# Altium schematic sheet Ethernet_PHY.SchDoc(Ethernet_PHY)
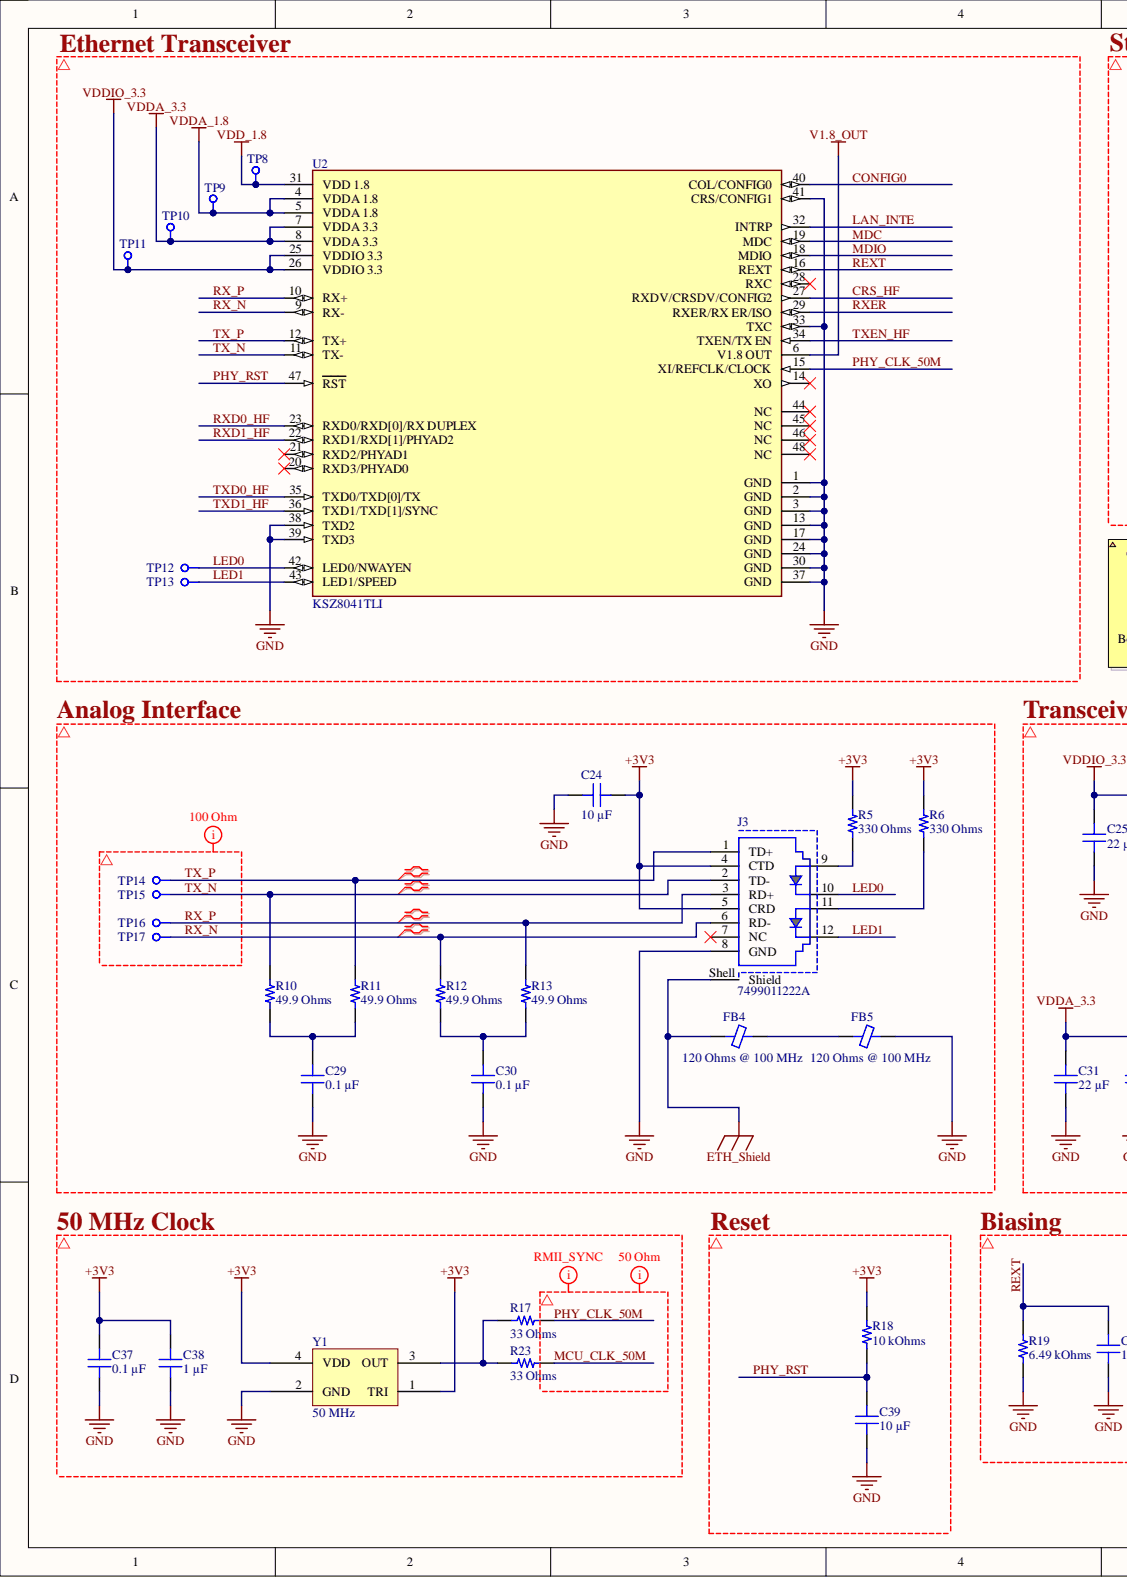
[diagram p0: Ethernet_PHY.SchDoc, left]
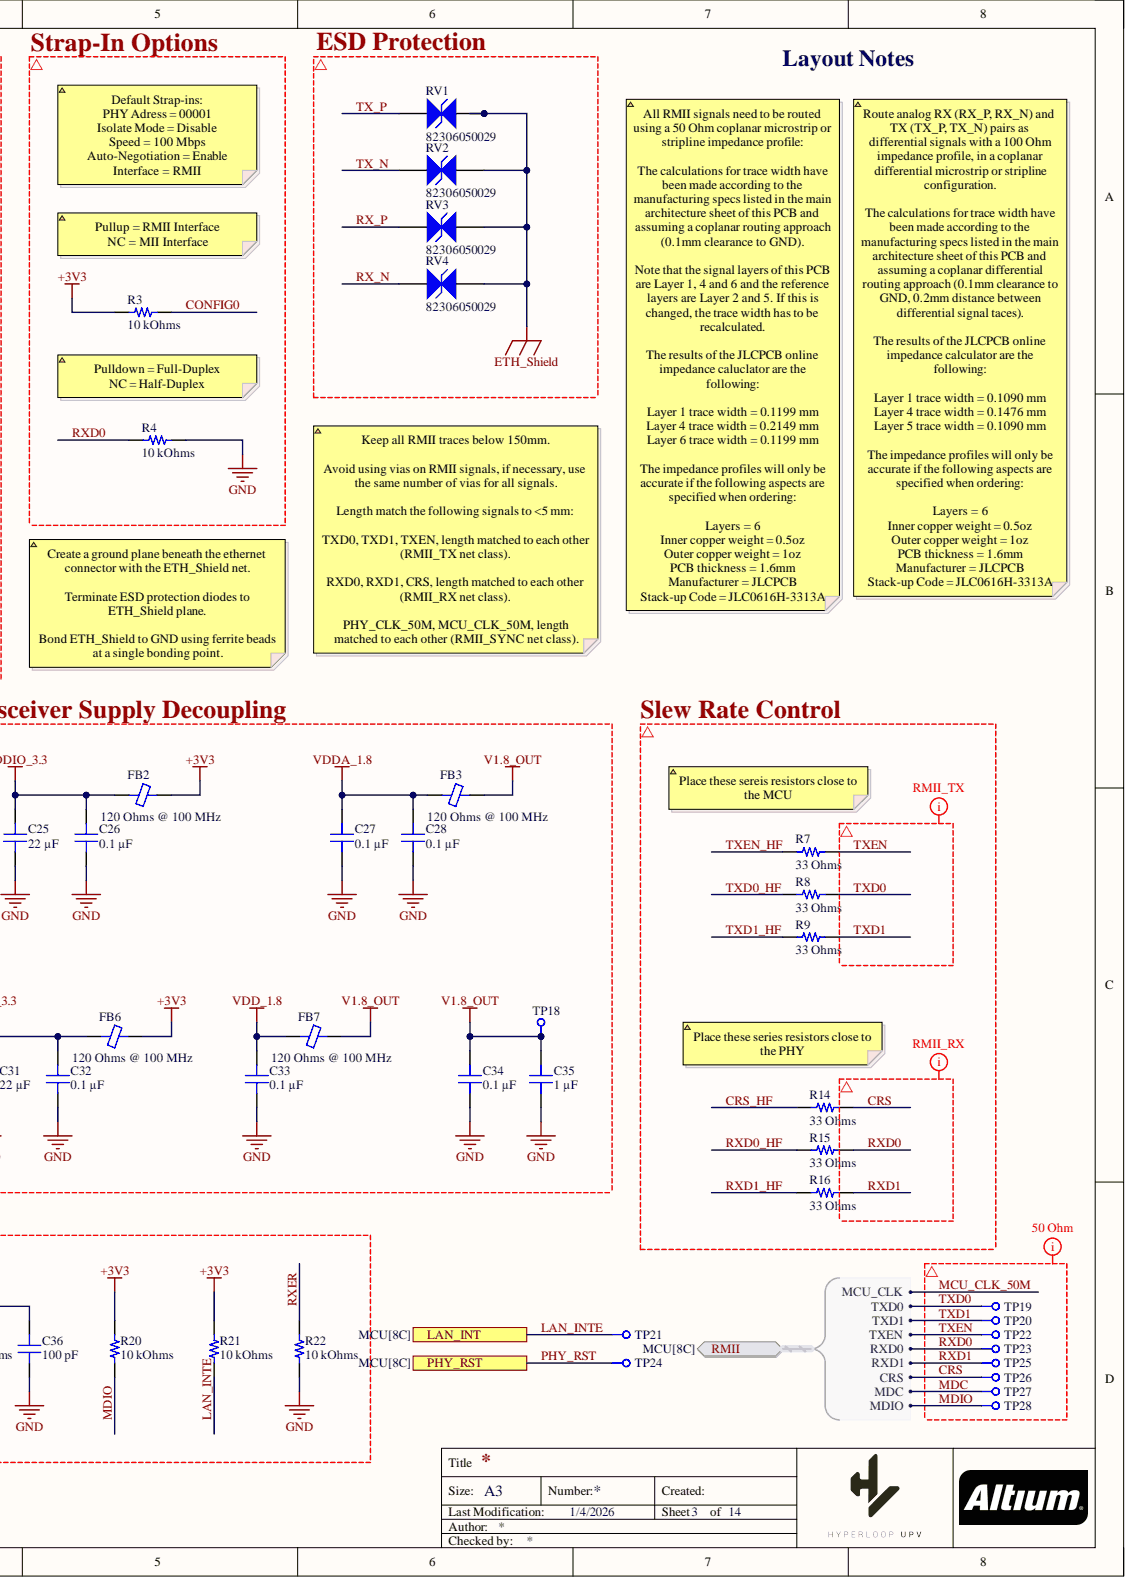
[diagram p1: Ethernet_PHY.SchDoc, right]

## Parts
C24  10 µF
C25  22 µF
C26  0.1 µF
C27  0.1 µF
C28  0.1 µF
C29  0.1 µF
C30  0.1 µF
C31  22 µF
C32  0.1 µF
C33  0.1 µF
C34  0.1 µF
C35  1 µF
C36  100 pF
C37  0.1 µF
C38  1 µF
C39  10 µF
FB2  120 Ohms @ 100 MHz
FB3  120 Ohms @ 100 MHz
FB4  120 Ohms @ 100 MHz
FB5  120 Ohms @ 100 MHz
FB6  120 Ohms @ 100 MHz
FB7  120 Ohms @ 100 MHz
J3  7499011222A
R3  10 kOhms
R4  10 kOhms
R5  330 Ohms
R6  330 Ohms
R7  33 Ohms
R8  33 Ohms
R9  33 Ohms
R10  49.9 Ohms
R11  49.9 Ohms
R12  49.9 Ohms
R13  49.9 Ohms
R14  33 Ohms
R15  33 Ohms
R16  33 Ohms
R17  33 Ohms
R18  10 kOhms
R19  6.49 kOhms
R20  10 kOhms
R21  10 kOhms
R22  10 kOhms
R23  33 Ohms
RV1  82306050029
RV2  82306050029
RV3  82306050029
RV4  82306050029
TP8  Test point 1.2mm  (value not drawn)
TP9  Test point 1.2mm  (value not drawn)
TP10  Test point 1.2mm  (value not drawn)
TP11  Test point 1.2mm  (value not drawn)
TP12  Test point 1.2mm  (value not drawn)
TP13  Test point 1.2mm  (value not drawn)
TP14  Test point 1.2mm  (value not drawn)
TP15  Test point 1.2mm  (value not drawn)
TP16  Test point 1.2mm  (value not drawn)
TP17  Test point 1.2mm  (value not drawn)
TP18  Test point 1.2mm  (value not drawn)
TP19  Test point 1.2mm  (value not drawn)
TP20  Test point 1.2mm  (value not drawn)
TP21  Test point 1.2mm  (value not drawn)
TP22  Test point 1.2mm  (value not drawn)
TP23  Test point 1.2mm  (value not drawn)
TP24  Test point 1.2mm  (value not drawn)
TP25  Test point 1.2mm  (value not drawn)
TP26  Test point 1.2mm  (value not drawn)
TP27  Test point 1.2mm  (value not drawn)
TP28  Test point 1.2mm  (value not drawn)
U2  KSZ8041TLI
Y1  50 MHz

## Ports
LAN_INT, PHY_RST, RMII, RMII.CRS, RMII.MCU_CLK, RMII.MDC, RMII.MDIO, RMII.RXD0, RMII.RXD1, RMII.TXD0, RMII.TXD1, RMII.TXEN

## Nets
+3V3: C24.2 C37.2 C38.2 FB2.2 FB6.2 J3.4(CTD) J3.5(CRD) R3.2 R5.2 R6.2 R18.2 R20.2 R21.2 Y1.1(TRI) Y1.4(VDD)
ETH_Shield: FB4.1 J3.Shell(Shield) RV1.2 RV2.2 RV3.2 RV4.2
GND: C24.1 C25.1 C26.1 C27.1 C28.1 C29.1 C30.1 C31.1 C32.1 C33.1 C34.1 C35.1 C36.1 C37.1 C38.1 C39.1 FB5.2 J3.8(GND) R4.1 R19.1 R22.1 U2.1(GND) U2.2(GND) U2.3(GND) U2.13(GND) U2.17(GND) U2.24(GND) U2.30(GND) U2.33(TXC) U2.37(GND) U2.38(TXD2) U2.39(TXD3) U2.41(CRS/CONFIG1) Y1.2(GND)
V1.8_OUT: C34.2 C35.2 FB3.2 FB7.2 TP18.1 U2.6(V1.8_OUT)
VDD_1.8: C33.2 FB7.1 TP8.1 U2.31(VDD_1.8)
VDDA_1.8: C27.2 C28.2 FB3.1 TP9.1 U2.4(VDDA_1.8) U2.5(VDDA_1.8)
VDDA_3.3: C31.2 C32.2 FB6.1 TP10.1 U2.7(VDDA_3.3) U2.8(VDDA_3.3)
VDDIO_3.3: C25.2 C26.2 FB2.1 TP11.1 U2.25(VDDIO_3.3) U2.26(VDDIO_3.3)
CONFIG0: R3.1 U2.40(COL/CONFIG0)
CRS: R14.2 TP26.1 ; port RMII
CRS_HF: R14.1 U2.27(RXDV/CRSDV/CONFIG2)
LAN_INTE: R21.1 TP21.1 U2.32(INTRP) ; port LAN_INT
LED0: J3.10 TP12.1 U2.42(LED0/NWAYEN)
LED1: J3.12 TP13.1 U2.43(LED1/SPEED)
MCU_CLK_50M: R23.2 ; port RMII
MDC: TP27.1 U2.19(MDC) ; port RMII
MDIO: R20.1 TP28.1 U2.18(MDIO) ; port RMII
PHY_CLK_50M: R17.2 U2.15(XI/REFCLK/CLOCK)
PHY_RST: C39.2 R18.1 TP24.1 U2.47(RST) ; port PHY_RST
REXT: C36.2 R19.2 U2.16(REXT)
RX_N: J3.6(RD-) R12.2 RV4.1 TP17.1 U2.9(RX-)
RX_P: J3.3(RD+) R13.2 RV3.1 TP16.1 U2.10(RX+)
RXD0: R4.2 R15.2 TP23.1 ; port RMII
RXD0_HF: R15.1 U2.23(RXD0/RXD[0]/RX_DUPLEX)
RXD1: R16.2 TP25.1 ; port RMII
RXD1_HF: R16.1 U2.22(RXD1/RXD[1]/PHYAD2)
RXER: R22.2 U2.29(RXER/RX_ER/ISO)
TX_N: J3.2(TD-) R10.2 RV2.1 TP15.1 U2.11(TX-)
TX_P: J3.1(TD+) R11.2 RV1.1 TP14.1 U2.12(TX+)
TXD0: R8.1 TP19.1 ; port RMII
TXD0_HF: R8.2 U2.35(TXD0/TXD[0]/TX)
TXD1: R9.1 TP20.1 ; port RMII
TXD1_HF: R9.2 U2.36(TXD1/TXD[1]/SYNC)
TXEN: R7.1 TP22.1 ; port RMII
TXEN_HF: R7.2 U2.34(TXEN/TX_EN)
NetC29_2: C29.2 R10.1 R11.1
NetC30_2: C30.2 R12.1 R13.1
NetFB4_2: FB4.2 FB5.1
NetJ3_9: J3.9 R5.1
NetJ3_11: J3.11 R6.1
NetR17_1: R17.1 R23.1 Y1.3(OUT)

Not connected: J3 7(NC); U2 14(XO) 20(RXD3/PHYAD0) 21(RXD2/PHYAD1) 28(RXC) 44(NC) 45(NC) 46(NC) 48(NC)

## Library data of the parts
C24 C39 = EMK316BJ106MD-T: CAP CER 10UF 16V X5R 1206; manufacturer: Taiyo Yuden; Database Table Name: Capacitors - Ceramic - 1206; Tolerance: ±20%; Applications: SMPS Filtering; Capacitance: 10 µF; Component Kind: Standard; componentlink1description: Datasheet; componentlink1url: http://www.yuden.co.jp/productdata/catalog/mlcc06_e.pdf; componentlink2description: DigiKey Link; componentlink2url: https://www.digikey.com/en/products/detail/taiyo-yuden/EMK316BJ106MD-T/6563474; Device Package: 1206 (3216 Metric); lastupdated: 04-Jun-24 19:10:41; Library Name: Celestial Altium Library - altium_library.DbLib; Lifecycle Status: Active; Minimum Order: 1; Mounting Type: Surface Mount, MLCC; Operating Temperature: -55°C ~ 85°C; Package / Case: 1206 (3216 Metric); packaging: TapeAndReel; Part Number: EMK316BJ106MD-T; Part Status: Active; partid: 18996; price: 0.04; series: M; Size / Dimension: 0.126" L x 0.063" W (3.20mm x 1.60mm); Supplier 1: DigiKey; Supplier Part Number 1: 587-4881-1-ND; Temperature Coefficient: X5R; Thickness (Max): 0.037" (0.95mm); Value: 10 µF; Voltage - Rated: 16V
C25 C31 = CL31A226KOCLFNC: CAP CER 22UF 16V X5R 1206; manufacturer: Samsung Electro-Mechanics; Database Table Name: Capacitors - Ceramic - 1206; Tolerance: ±10%; Applications: General Purpose; Capacitance: 22 µF; Component Kind: Standard; componentlink1description: Datasheet; componentlink1url: http://www.samsungsem.com/kr/support/product-search/mlcc/CL31A226KOCLFNC.jsp; componentlink2description: DigiKey Link; componentlink2url: https://www.digikey.com/en/products/detail/samsung-electro-mechanics/CL31A226KOCLFNC/3888383; Device Package: 1206 (3216 Metric); lastupdated: 04-Jun-24 19:10:41; Library Name: Celestial Altium Library - altium_library.DbLib; Lifecycle Status: Active; Minimum Order: 1; Mounting Type: Surface Mount, MLCC; Operating Temperature: -55°C ~ 85°C; Package / Case: 1206 (3216 Metric); packaging: TapeAndReel; Part Number: CL31A226KOCLFNC; Part Status: Active; partid: 18940; price: 0.09; series: CL; Size / Dimension: 0.126" L x 0.063" W (3.20mm x 1.60mm); Supplier 1: DigiKey; Supplier Part Number 1: 1276-2725-1-ND; Temperature Coefficient: X5R; Thickness (Max): 0.037" (0.95mm); Value: 22 µF; Voltage - Rated: 16V
C26-C30 C32-C34 C37 = C0603C104K5RAC7411: CAP CER 0.1UF 50V X7R 0603; manufacturer: KEMET; Database Table Name: Capacitors - Ceramic - 0603; Tolerance: ±10%; Applications: Bypass, Decoupling; Capacitance: 0.1 µF; Component Kind: Standard; componentlink1description: Datasheet; componentlink1url: https://api.kemet.com/component-edge/download/datasheet/C0603C104K5RAC7411.pdf; componentlink2description: DigiKey Link; componentlink2url: https://www.digikey.com/en/products/detail/kemet/C0603C104K5RAC7411/3317013; Device Package: 0603 (1608 Metric); lastupdated: 09-Feb-24 20:10:30; Library Name: Celestial Altium Library - altium_library.DbLib; Lifecycle Status: Active; Minimum Order: 1; Mounting Type: Surface Mount, MLCC; Operating Temperature: -55°C ~ 125°C; Package / Case: 0603 (1608 Metric); packaging: TapeAndReel; Part Number: C0603C104K5RAC7411; Part Status: Active; partid: 2233; price: 0.01; series: SMD Comm X7R; Size / Dimension: 0.063" L x 0.031" W (1.60mm x 0.80mm); Supplier 1: DigiKey; Supplier Part Number 1: 399-C0603C104K5RAC7411CT-ND; Temperature Coefficient: X7R; Thickness (Max): 0.035" (0.90mm); Value: 0.1 µF; Voltage - Rated: 50V
C35 C38 = C0603C105K4RACAUTO: CAP CER 1UF 16V X7R 0603; manufacturer: KEMET; Database Table Name: Capacitors - Ceramic - 0603; Tolerance: ±10%; Applications: Automotive, Bypass, Decoupling; Capacitance: 1 µF; Component Kind: Standard; componentlink1description: Datasheet; componentlink1url: https://api.kemet.com/component-edge/download/datasheet/C0603C105K4RACAUTO.pdf; componentlink2description: DigiKey Link; componentlink2url: https://www.digikey.com/en/products/detail/kemet/C0603C105K4RACAUTO/8635831; Device Package: 0603 (1608 Metric); lastupdated: 09-Feb-24 20:10:30; Library Name: Celestial Altium Library - altium_library.DbLib; Lifecycle Status: Active; Minimum Order: 1; Mounting Type: Surface Mount, MLCC; Operating Temperature: -55°C ~ 125°C; Package / Case: 0603 (1608 Metric); packaging: TapeAndReel; Part Number: C0603C105K4RACAUTO; Part Status: Active; partid: 2179; price: 0.01; Ratings: AEC-Q200; series: SMD Auto X7R; Size / Dimension: 0.063" L x 0.031" W (1.60mm x 0.80mm); Supplier 1: DigiKey; Supplier Part Number 1: 399-17704-1-ND; Temperature Coefficient: X7R; Thickness (Max): 0.037" (0.95mm); Value: 1 µF; Voltage - Rated: 16V
C36 = C0603C101K5GACAUTO: CAP CER 100PF 50V C0G/NP0 0603; manufacturer: KEMET; Database Table Name: Capacitors - Ceramic - 0603; Temperature Coefficient: C0G, NP0; Tolerance: ±10%; Applications: Automotive; Capacitance: 100 pF; Component Kind: Standard; componentlink1description: Datasheet; componentlink1url: https://api.kemet.com/component-edge/download/datasheet/C0603C101K5GACAUTO.pdf; componentlink2description: DigiKey Link; componentlink2url: https://www.digikey.com/en/products/detail/kemet/C0603C101K5GACAUTO/3314842; Device Package: 0603 (1608 Metric); Features: Low ESL; lastupdated: 09-Feb-24 20:10:30; Library Name: Celestial Altium Library - altium_library.DbLib; Lifecycle Status: Active; Minimum Order: 1; Mounting Type: Surface Mount, MLCC; Operating Temperature: -55°C ~ 125°C; Package / Case: 0603 (1608 Metric); packaging: TapeAndReel; Part Number: C0603C101K5GACAUTO; Part Status: Active; partid: 2201; price: 0.01; Ratings: AEC-Q200; series: SMD Auto C0G; Size / Dimension: 0.063" L x 0.031" W (1.60mm x 0.80mm); Supplier 1: DigiKey; Supplier Part Number 1: 399-6843-1-ND; Thickness (Max): 0.034" (0.87mm); Value: 100 pF; Voltage - Rated: 50V
FB2-FB7 = BLM21BB121SN1D: FERRITE BEAD 120 OHM 0805 1LN; Manufacturer: Murata Electronics; Current Rating (Max): 600mA; Database Table Name: Filters - Ferrite Beads and Chips; DC Resistance (DCR) (Max): 190mOhm; Filter Type: Signal Line; Number of Lines: 1; Component Kind: Standard; ComponentLink1Description: Datasheet; ComponentLink1URL: https://www.murata.com/en-us/products/en-us/products/productdata/8796738977822/ENFA0005.pdf; ComponentLink2Description: DigiKey Link; ComponentLink2URL: https://www.digikey.com/en/products/detail/murata-electronics/BLM21BB121SN1D/584252; Device Package: 0805 (2012 Metric); Height (Max): 0.041" (1.05mm); Impedance @ Frequency: 120 Ohms @ 100 MHz; LastUpdated: 19/09/2022 13:44:16; Library Name: Celestial Altium Library - altium_library.DbLib; Lifecycle Status: Active; Minimum Order: 1; Mounting Type: Surface Mount; Operating Temperature: -55°C ~ 125°C; Package / Case: 0805 (2012 Metric); Packaging: TapeAndReel; Part Number: BLM21BB121SN1D; Part Status: Active; PartId: 1466; Price: 0.03; Series: EMIFIL®, BLM21; Size / Dimension: 0.079" L x 0.049" W (2.00mm x 1.25mm); Supplier 1: DigiKey; Supplier Part Number 1: 490-1042-1-ND; Value: 120 Ohms @ 100 MHz
J3 = CMP-03913-28997934-2: 7499011222A Through Hole Lan Ethernet Transformer, 13.95 x 16 x 21.6mm, -40 +85 °C; Connector Type: RJ45; Direction: Down; Gender: Female; Inductance: 350µH; Insertion Loss (dB): -1dB; Number of Contacts: 8; Number of Cores per Jack: 4; Number of Ports: 1; Pitch: 2.54mm; Shielding: Shielded; Color: Green; Component Kind: Standard; Contact Material: Ferrite, Gold, Nickel; Contact Plating: Gold; Depth: 21.6mm; Flammability Rating: UL94 V-0; Height: 14mm; Housing Material: Thermoplastic; Lead Free: Lead Free; Length: 22mm; Library Name: Altium Content Vault; Max Operating Temperature: 85°C; Min Operating Temperature: -40°C; Mount: Through Hole; NexarPartId: 28997934; Number of Positions: 8; Number of Positions Loaded: 8; Number of Rows: 1; Orientation: Right Angle; REACH SVHC: Unknown; RoHS: Compliant; Termination: Through Hole; Width: 16mm
R3 R4 R18 R20-R22 = RNCS0603BKE10K0: RES 10K OHM 0.1% 1/16W 0603; Manufacturer: Stackpole Electronics Inc; Database Table Name: Resistors - Surface Mount - 0603; Power (Watts): 0.063W, 1/16W; Temperature Coefficient: ±25ppm/°C; Component Kind: Standard; ComponentLink1Description: Datasheet; ComponentLink1URL: https://www.seielect.com/catalog/sei-rncs_rnch.pdf; ComponentLink2Description: DigiKey Link; ComponentLink2URL: https://www.digikey.com/en/products/detail/stackpole-electronics-inc/RNCS0603BKE10K0/1708836; Composition: Thin Film; Device Package: 0603 (1608 Metric); Features: Anti-Corrosive, Automotive AEC-Q200, Moisture Resistant; Height - Seated (Max): 0.022" (0.55mm); LastUpdated: 09-Feb-24 20:43:37; Library Name: Celestial Altium Library - altium_library.DbLib; Lifecycle Status: Active; Minimum Order: 1; Number of Terminations: 2; Operating Temperature: -55°C ~ 155°C; Package / Case: 0603 (1608 Metric); Packaging: TapeAndReel; Part Number: RNCS0603BKE10K0; Part Status: Active; PartId: 4838; Price: 0.06; Ratings: AEC-Q200; Resistance: 10 kOhms; Series: RNCS; Size / Dimension: 0.061" L x 0.031" W (1.55mm x 0.80mm); Supplier 1: DigiKey; Supplier Device Package: 0603; Supplier Part Number 1: RNCS0603BKE10K0CT-ND; Tolerance: ±0.1%; Value: 10 kOhms
R5 R6 = CRGH0603F330R: RES SMD 330 OHM 1% 1/5W 0603; Manufacturer: TE Connectivity Passive Product; Database Table Name: Resistors - Surface Mount - 0603; Power (Watts): 0.2W, 1/5W; Temperature Coefficient: ±100ppm/°C; Component Kind: Standard; ComponentLink1Description: Datasheet; ComponentLink1URL: https://www.te.com/commerce/DocumentDelivery/DDEController?Action=srchrtrv&DocNm=1773204-1&DocType=DS&DocLang=English; ComponentLink2Description: DigiKey Link; ComponentLink2URL: https://www.digikey.com/en/products/detail/te-connectivity-passive-product/CRGH0603F330R/5586961; Composition: Thick Film; Device Package: 0603 (1608 Metric); Height - Seated (Max): 0.022" (0.55mm); LastUpdated: 09-Feb-24 20:43:33; Library Name: Celestial Altium Library - altium_library.DbLib; Lifecycle Status: Active; Minimum Order: 1; Number of Terminations: 2; Operating Temperature: -55°C ~ 155°C; Package / Case: 0603 (1608 Metric); Packaging: TapeAndReel; Part Number: CRGH0603F330R; Part Status: Active; PartId: 5733; Price: 0.01; Resistance: 330 Ohms; Series: CRGH, Neohm; Size / Dimension: 0.063" L x 0.031" W (1.60mm x 0.80mm); Supplier 1: DigiKey; Supplier Device Package: 0603; Supplier Part Number 1: A129541CT-ND; Tolerance: ±1%; Value: 330 Ohms
R7-R9 R14-R17 R23 = CPF0603B33RE: RES SMD 33 OHM 0.1% 1/16W 0603; Manufacturer: TE Connectivity Passive Product; Database Table Name: Resistors - Surface Mount - 0603; Power (Watts): 0.063W, 1/16W; Temperature Coefficient: ±25ppm/°C; Component Kind: Standard; ComponentLink1Description: Datasheet; ComponentLink1URL: https://www.te.com/commerce/DocumentDelivery/DDEController?Action=srchrtrv&DocNm=1773200&DocType=DS&DocLang=English; ComponentLink2Description: DigiKey Link; ComponentLink2URL: https://www.digikey.com/en/products/detail/te-connectivity-passive-product/CPF0603B33RE/2381854; Composition: Thin Film; Device Package: 0603 (1608 Metric); Height - Seated (Max): 0.022" (0.55mm); LastUpdated: 09-Feb-24 20:43:37; Library Name: Celestial Altium Library - altium_library.DbLib; Lifecycle Status: Active; Minimum Order: 1; Number of Terminations: 2; Operating Temperature: -55°C ~ 155°C; Package / Case: 0603 (1608 Metric); Packaging: TapeAndReel; Part Number: CPF0603B33RE; Part Status: Active; PartId: 4881; Price: 0.09; Resistance: 33 Ohms; Series: CPF, Neohm; Size / Dimension: 0.061" L x 0.031" W (1.55mm x 0.80mm); Supplier 1: DigiKey; Supplier Device Package: 0603; Supplier Part Number 1: A119909CT-ND; Tolerance: ±0.1%; Value: 33 Ohms
R10-R13 = RCC060349R9FKEA: RCC0603 100 49R9 1% ET1 E3; Manufacturer: Vishay Dale; Database Table Name: Resistors - Surface Mount - 0603; Power (Watts): 0.2W, 1/5W; Temperature Coefficient: ±100ppm/°C; Component Kind: Standard; ComponentLink1Description: Datasheet; ComponentLink1URL: https://www.vishay.com/docs/20066/rcce3.pdf; ComponentLink2Description: DigiKey Link; ComponentLink2URL: https://www.digikey.com/en/products/detail/vishay-dale/RCC060349R9FKEA/12084267; Composition: Thick Film; Device Package: 0603 (1608 Metric); Features: Automotive AEC-Q200; Height - Seated (Max): 0.020" (0.50mm); LastUpdated: 09-Feb-24 20:41:18; Library Name: Celestial Altium Library - altium_library.DbLib; Lifecycle Status: Active; Minimum Order: 1; Number of Terminations: 2; Operating Temperature: -55°C ~ 155°C; Package / Case: 0603 (1608 Metric); Packaging: TapeAndReel; Part Number: RCC060349R9FKEA; Part Status: Active; PartId: 61995; Price: 0.01; Ratings: AEC-Q200; Resistance: 49.9 Ohms; Series: RCC e3; Size / Dimension: 0.061" L x 0.033" W (1.55mm x 0.85mm); Supplier 1: DigiKey; Supplier Device Package: 0603; Supplier Part Number 1: 541-RCC060349R9FKEACT-ND; Tolerance: ±1%; Value: 49.9 Ohms
R19 = RQ73C1J6K49BTDF: RQ 0603 6K49 0.1% 10PPM 1K RL; Manufacturer: TE Connectivity Passive Product; Database Table Name: Resistors - Surface Mount - 0603; Power (Watts): 0.15W; Temperature Coefficient: ±10ppm/°C; Component Kind: Standard; ComponentLink1Description: Datasheet; ComponentLink1URL: https://www.te.com/commerce/DocumentDelivery/DDEController?Action=srchrtrv&DocNm=1773270-2&DocType=DS&DocLang=English; ComponentLink2Description: DigiKey Link; ComponentLink2URL: https://www.digikey.com/en/products/detail/te-connectivity-passive-product/RQ73C1J6K49BTDF/13941765; Composition: Thin Film; Device Package: 0603 (1608 Metric); Features: Anti-Sulfur, Automotive AEC-Q200, Moisture Resistant; Height - Seated (Max): 0.022" (0.55mm); LastUpdated: 09-Feb-24 20:41:24; Library Name: Celestial Altium Library - altium_library.DbLib; Lifecycle Status: Active; Minimum Order: 1; Number of Terminations: 2; Operating Temperature: -55°C ~ 155°C; Package / Case: 0603 (1608 Metric); Packaging: TapeAndReel; Part Number: RQ73C1J6K49BTDF; Part Status: Active; PartId: 62581; Price: 0.38; Ratings: AEC-Q200; Resistance: 6.49 kOhms; Series: RQ73; Size / Dimension: 0.061" L x 0.031" W (1.55mm x 0.80mm); Supplier 1: DigiKey; Supplier Device Package: 0603; Supplier Part Number 1: 1712-RQ73C1J6K49BTDFCT-ND; Tolerance: ±0.1%; Value: 6.49 kOhms
RV1-RV4 = CMP-1424-00003-2: NexarPartId: 18986578; Capacitance: 200fF; Clamping Voltage: 30V; Direction: Bidirectional; Element Configuration: Single; ESD Protection: Yes; Insulation Resistance: 10MΩ; Leakage Current: 10nA; Max Reverse Leakage Current: 10mA; Number of Circuits: 1; Operating Supply Voltage: 5V; Test Current: 1A; Case Code (Imperial): 0603; Case Code (Metric): 1608; Case/Package: 0603; Component Kind: Standard; Height: 900µm; Length: 1.6mm; Library Name: Altium Content Vault; Max Operating Temperature: 85°C; Min Operating Temperature: -40°C; Mount: Surface Mount; Number of Pins: 2; Packaging: Digi-Reel®; REACH SVHC: Unknown; Reverse Standoff Voltage: 5V; RoHS: Compliant; Voltage Rating (DC): 5V; Width: 800µm; Working Voltage: 5V
TP8-TP28 = CMP-006-00250-2: 1.2mm Test point; Component Kind: Standard; Library Name: Hyperloop UPV
U2 = CMP-06281-000045-1: IC ETHERNET PHY IND 48-TQFP; Data Rate: 100Mbps; Max Power Dissipation: 180mW; Max Supply Voltage: 3.465V; Min Supply Voltage: 3.135V; Nominal Supply Current: 58.3A; Number of Channels: 1; Operating Supply Voltage: 3.3V; Simplex/Duplex: Full Duplex; Case/Package: TQFP; Component Kind: Standard; Height: 1mm; Lead Free: Lead Free; Length: 7mm; Library Name: Altium Content Vault; Max Operating Temperature: 85°C; Min Operating Temperature: -40°C; Mount: Surface Mount; Number of Functions: 1; Number of Pins: 48; Number of Transceivers: 1; Package Quantity: 250; Packaging: Tray; Radiation Hardening: No; REACH SVHC: No SVHC; Series: -; Supply Current: 58.3A; Supply Voltage-Nom: 3.465V; Width: 7mm
Y1 = ASE-50.000MHZ-LR-T: XTAL OSC XO 50.0000MHZ CMOS SMD; Manufacturer: Abracon LLC; Base Resonator: Crystal; Current - Supply (Max): 19mA; Database Table Name: Oscillators - Oscillators; Frequency Stability: ±25ppm; Function: Enable/Disable; Voltage - Supply: 3.3V; Component Kind: Standard; ComponentLink1Description: Datasheet; ComponentLink1URL: https://abracon.com/Oscillators/ASEseries.pdf; ComponentLink2Description: DigiKey Link; ComponentLink2URL: https://www.digikey.com/en/products/detail/abracon-llc/ASE-50-000MHZ-LR-T/2637780; Frequency: 50 MHz; Height - Seated (Max): 0.047" (1.20mm); LastUpdated: 19/09/2022 13:51:44; Library Name: Celestial Altium Library - altium_library.DbLib; Lifecycle Status: Active; Minimum Order: 1; Mounting Type: Surface Mount; Operating Temperature: -40°C ~ 85°C; Output: CMOS; Package / Case: 4-SMD, No Lead; Packaging: TapeAndReel; Part Number: ASE-50.000MHZ-LR-T; Part Status: Active; PartId: 131; Price: 1.22; Series: ASE; Size / Dimension: 0.126" L x 0.098" W (3.20mm x 2.50mm); Supplier 1: DigiKey; Supplier Part Number 1: 535-13431-1-ND; Type: XO (Standard); Value: 50 MHz
